# Altium schematic sheet MCU_PWR.SchDoc
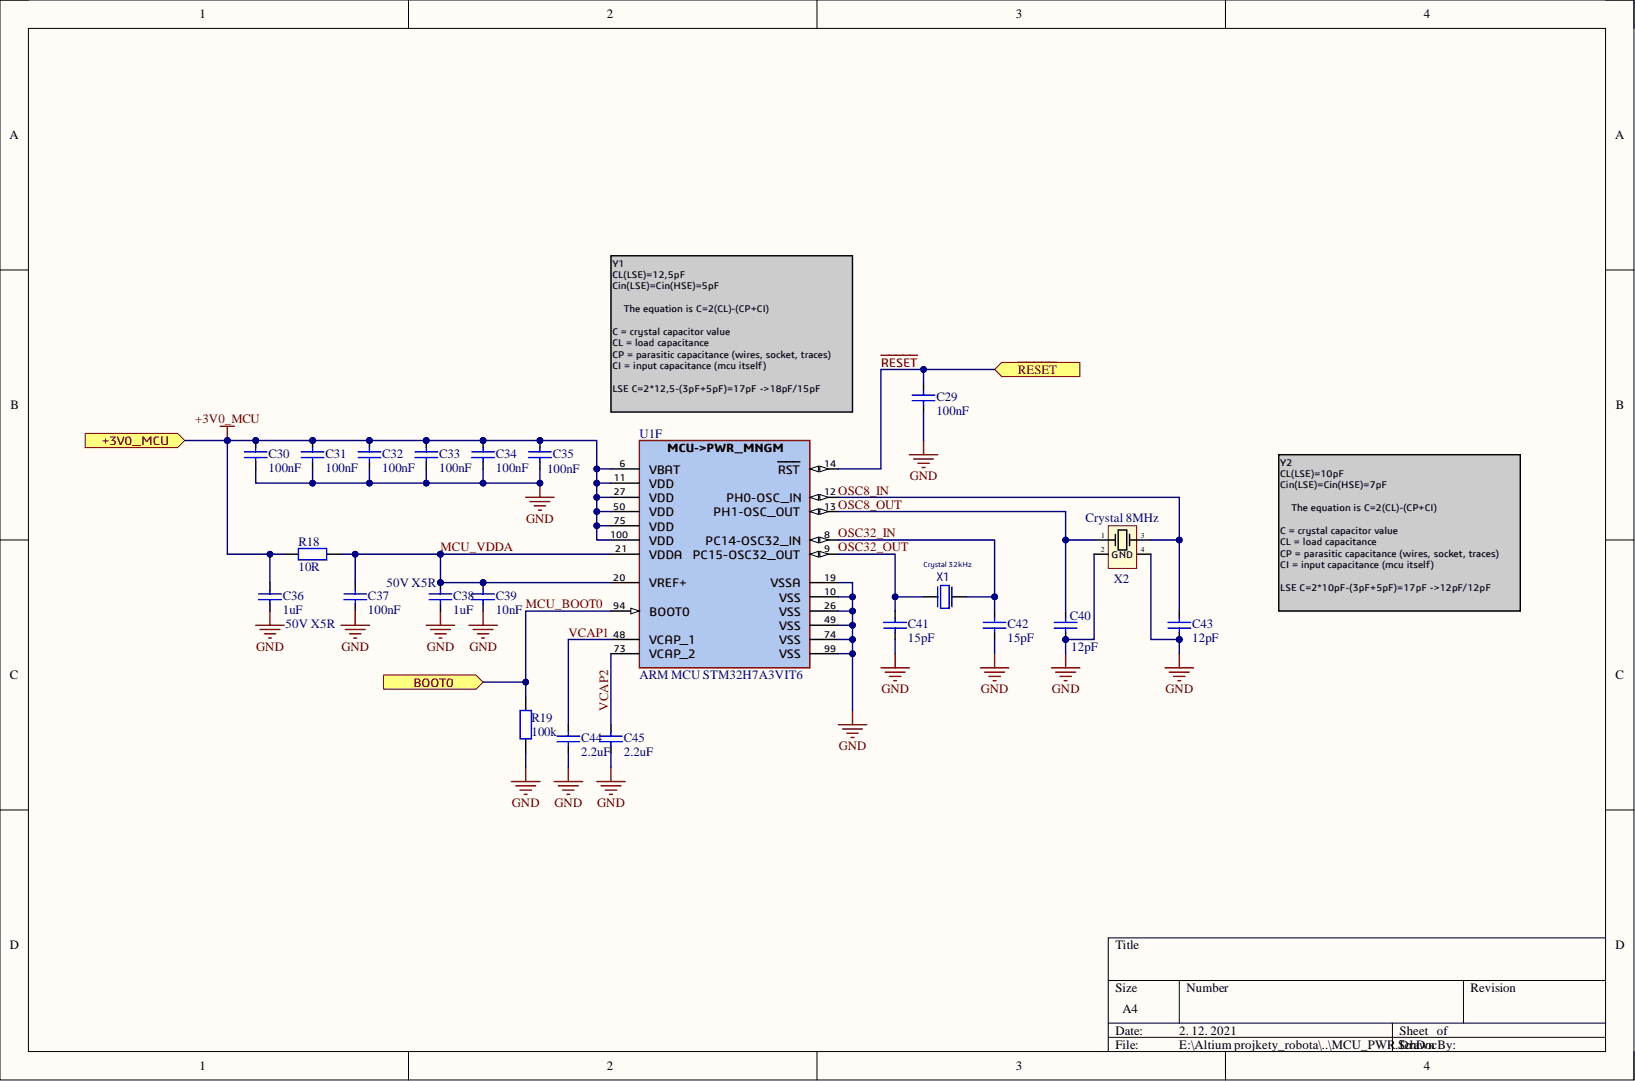
[diagram p0: MCU_PWR.SchDoc]

## Parts
C29  100nF  [CAPACITOR_0402_(1005)]
C30  100nF  [CAPACITOR_0402_(1005)]
C31  100nF  [CAPACITOR_0402_(1005)]
C32  100nF  [CAPACITOR_0402_(1005)]
C33  100nF  [CAPACITOR_0402_(1005)]
C34  100nF  [CAPACITOR_0402_(1005)]
C35  100nF  [CAPACITOR_0402_(1005)]
C36  1uF  Voltage Rating (DC): 50V  [CAPACITOR_0603_(1608)]
C37  100nF  [CAPACITOR_0402_(1005)]
C38  1uF  Dielectric: X5R  [CAPACITOR_0603_(1608)]
C39  10nF  [CAPACITOR_0402_(1005)]
C40  12pF  [CAPACITOR_0402_(1005)]
C41  15pF  [CAPACITOR_0402_(1005)]
C42  15pF  [CAPACITOR_0402_(1005)]
C43  12pF  [CAPACITOR_0402_(1005)]
C44  2.2uF  [CAPACITOR_0603_(1608)]
C45  2.2uF  [CAPACITOR_0603_(1608)]
R18  10R  [RESISTOR_0603_(1608)]
R19  100k  [RESISTOR_0603_(1608)]
U1  ARM MCU STM32H7A3VIT6  Manufacturer Part Number: STM32H7A3VIT6  [STM-LQFP100_N - Edited]  (part F)
X1  Crystal 32kHz  [ABS07]
X2  Crystal 8MHz  Frequency: 8MHz  [SMD Crystal 5.0 X 3.2 X 1.1mm]

## Ports
+3V0_MCU, BOOT0, ~{RESET}

## Nets
GND: C29.2 C30.2 C31.2 C32.2 C33.2 C34.2 C35.2 C36.2 C37.2 C38.2 C39.2 C40.1 C41.1 C42.1 C43.1 C44.1 C45.1 R19.1 U1.10(VSS) U1.19(VSSA) U1.26(VSS) U1.49(VSS) U1.74(VSS) U1.99(VSS) X2.2 X2.4(GND)
+3V0_MCU: C30.1 C31.1 C32.1 C33.1 C34.1 C35.1 C36.1 R18.1 U1.6(VBAT) U1.11(VDD) U1.27(VDD) U1.50(VDD) U1.75(VDD) U1.100(VDD) ; port +3V0_MCU
MCU_BOOT0: R19.2 U1.94(BOOT0) ; port BOOT0
MCU_VDDA: C37.1 C38.1 C39.1 R18.2 U1.20(VREF+) U1.21(VDDA)
OSC8_IN: C43.2 U1.12(PH0-OSC_IN) X2.3
OSC8_OUT: C40.2 U1.13(PH1-OSC_OUT) X2.1
OSC32_IN: C42.2 U1.8(PC14-OSC32_IN) X1.1
OSC32_OUT: C41.2 U1.9(PC15-OSC32_OUT) X1.2
~{RESET}: C29.1 U1.14(RST) ; port ~{RESET}
VCAP1: C44.2 U1.48(VCAP_1)
VCAP2: C45.2 U1.73(VCAP_2)

## Library data of the parts
C29-C35 C37 C39-C43 = CAPACITOR: ESR: 0.28R; Voltage Rating: 10V; Component Kind: Standard; Description: CAPACITOR; Library Name: RLCFB.SchLib
  C29-C35 C37: Capacitance: 100nF
  C39: Capacitance: 10nF
  C40 C43: Capacitance: 12pF
  C41 C42: Capacitance: 15pF
C36 = CAPACITOR: Manufacturer: Taiyo Yuden; Manufacturer Part Number 1: UMK107BJ105KA-T; Dielectric: X5R; ESR: 0.28R; Tolerance: 10%; Voltage Rating: 50V X5R; Capacitance: 1uF; Case Code (Imperial): 0603; Case Code (Metric): 1608; Case/Package: 0603; Component Kind: Standard; ComponentLink1Description: https://datasheet.ciiva.com/5744/1697715-5744913.pdf?src-supplier=Farnell; ComponentLink1URL: https://datasheet.ciiva.com/5744/1697715-5744913.pdf?src-supplier=Farnell; ComponentLink2Description: https://datasheet.ciiva.com/11601/umk107bj105ka-t-ss-11601811.pdf?src-supplier=Avnet; ComponentLink2URL: https://datasheet.ciiva.com/11601/umk107bj105ka-t-ss-11601811.pdf?src-supplier=Avnet; ComponentLink3Description: https://datasheet.ciiva.com/15922/336umk107bj105ka-t-15922611.pdf?src-supplier=Onlinecomponents.com; ComponentLink3URL: https://datasheet.ciiva.com/15922/336umk107bj105ka-t-15922611.pdf?src-supplier=Onlinecomponents.com; ComponentLink4Description: https://datasheet.ciiva.com/134/umk107bj105ka-t-ss-134740.pdf?src-supplier=Digi-Key; ComponentLink4URL: https://datasheet.ciiva.com/134/umk107bj105ka-t-ss-134740.pdf?src-supplier=Digi-Key; ComponentLink5Description: https://datasheet.ciiva.com/30199/43umk107bj105ka-t-30199791.pdf?src-supplier=Onlinecomponents.com; ComponentLink5URL: https://datasheet.ciiva.com/30199/43umk107bj105ka-t-30199791.pdf?src-supplier=Onlinecomponents.com; ComponentLink6Description: https://datasheet.ciiva.com/22694/1697715-22694278.pdf?src-supplier=Element14; ComponentLink6URL: https://datasheet.ciiva.com/22694/1697715-22694278.pdf?src-supplier=Element14; ComponentLink7Description: https://datasheet.ciiva.com/7975/1697715-7975608.pdf?src-supplier=Element14; ComponentLink7URL: https://datasheet.ciiva.com/7975/1697715-7975608.pdf?src-supplier=Element14; ComponentLink8Description: https://datasheet.ciiva.com/7975/mlcc-all-e-7975898.pdf?src-supplier=Element14; ComponentLink8URL: https://datasheet.ciiva.com/7975/mlcc-all-e-7975898.pdf?src-supplier=Element14; ComponentLink9Description: https://datasheet.ciiva.com/5427/mlcc-all-e-541559-5427036.pdf?src-supplier=Mouser; ComponentLink9URL: https://datasheet.ciiva.com/5427/mlcc-all-e-541559-5427036.pdf?src-supplier=Mouser; Contact Plating: Nickel; Depth: 0.8mm; Description: CAPACITOR; Halogen Free: Halogen Free; Height: 0.8mm; Length: 1.6mm; Max Operating Temperature: 85°C; Min Operating Temperature: -55°C; Mount: Surface Mount; Number of Pins: 2; Package Quantity: 1; Packaging: Tape and Reel; Pricing 1: 10=0,14300, 100=0,06560, 500=0,04260, 1000=0,03940, 2000=0,03750, 4000=0,02830, 20000=0,02630 (EUR); Radiation Hardening: No; REACH SVHC: Unknown; RoHS: Yes; Stock 1: 372983; Supplier 1: Farnell; Supplier Part Number 1: 2112858; Termination: SMD/SMT; Thickness: 0.035inch; Width: 0.031inch; Library Name: RLCFB.SchLib
C38 = CAPACITOR: Manufacturer: Taiyo Yuden; Manufacturer Part Number 1: UMK107BJ105KA-T; ESR: 0.28R; Tolerance: 10%; Voltage Rating: 50V X5R; Voltage Rating (DC): 50V; Capacitance: 1uF; Case Code (Imperial): 0603; Case Code (Metric): 1608; Case/Package: 0603; Component Kind: Standard; ComponentLink1Description: https://datasheet.ciiva.com/5744/1697715-5744913.pdf?src-supplier=Farnell; ComponentLink1URL: https://datasheet.ciiva.com/5744/1697715-5744913.pdf?src-supplier=Farnell; ComponentLink2Description: https://datasheet.ciiva.com/11601/umk107bj105ka-t-ss-11601811.pdf?src-supplier=Avnet; ComponentLink2URL: https://datasheet.ciiva.com/11601/umk107bj105ka-t-ss-11601811.pdf?src-supplier=Avnet; ComponentLink3Description: https://datasheet.ciiva.com/15922/336umk107bj105ka-t-15922611.pdf?src-supplier=Onlinecomponents.com; ComponentLink3URL: https://datasheet.ciiva.com/15922/336umk107bj105ka-t-15922611.pdf?src-supplier=Onlinecomponents.com; ComponentLink4Description: https://datasheet.ciiva.com/134/umk107bj105ka-t-ss-134740.pdf?src-supplier=Digi-Key; ComponentLink4URL: https://datasheet.ciiva.com/134/umk107bj105ka-t-ss-134740.pdf?src-supplier=Digi-Key; ComponentLink5Description: https://datasheet.ciiva.com/30199/43umk107bj105ka-t-30199791.pdf?src-supplier=Onlinecomponents.com; ComponentLink5URL: https://datasheet.ciiva.com/30199/43umk107bj105ka-t-30199791.pdf?src-supplier=Onlinecomponents.com; ComponentLink6Description: https://datasheet.ciiva.com/22694/1697715-22694278.pdf?src-supplier=Element14; ComponentLink6URL: https://datasheet.ciiva.com/22694/1697715-22694278.pdf?src-supplier=Element14; ComponentLink7Description: https://datasheet.ciiva.com/7975/1697715-7975608.pdf?src-supplier=Element14; ComponentLink7URL: https://datasheet.ciiva.com/7975/1697715-7975608.pdf?src-supplier=Element14; ComponentLink8Description: https://datasheet.ciiva.com/7975/mlcc-all-e-7975898.pdf?src-supplier=Element14; ComponentLink8URL: https://datasheet.ciiva.com/7975/mlcc-all-e-7975898.pdf?src-supplier=Element14; ComponentLink9Description: https://datasheet.ciiva.com/5427/mlcc-all-e-541559-5427036.pdf?src-supplier=Mouser; ComponentLink9URL: https://datasheet.ciiva.com/5427/mlcc-all-e-541559-5427036.pdf?src-supplier=Mouser; Contact Plating: Nickel; Depth: 0.8mm; Description: CAPACITOR; Halogen Free: Halogen Free; Height: 0.8mm; Length: 1.6mm; Max Operating Temperature: 85°C; Min Operating Temperature: -55°C; Mount: Surface Mount; Number of Pins: 2; Package Quantity: 1; Packaging: Tape and Reel; Pricing 1: 10=0,14300, 100=0,06560, 500=0,04260, 1000=0,03940, 2000=0,03750, 4000=0,02830, 20000=0,02630 (EUR); Radiation Hardening: No; REACH SVHC: Unknown; RoHS: Yes; Stock 1: 372983; Supplier 1: Farnell; Supplier Part Number 1: 2112858; Termination: SMD/SMT; Thickness: 0.035inch; Width: 0.031inch; Library Name: RLCFB.SchLib
C44 C45 = CAPACITOR: Manufacturer: Murata; Manufacturer Part Number 1: GRT188R61H225KE13D; Dielectric: X5R; Tolerance: 10%; Voltage Rating: 50V; Capacitance: 2.2uF; Case Code (Imperial): 0603; Case Code (Metric): 1608; Case/Package: 0603; Component Kind: Standard; ComponentLink1Description: http://www.farnell.com/datasheets/2165960.pdf?src-supplier=Farnell; ComponentLink1URL: http://www.farnell.com/datasheets/2165960.pdf?src-supplier=Farnell; ComponentLink2Description: http://search.murata.co.jp/Ceramy/image/img/A01X/G101/ENG/GRT188R61H225KE13-01.pdf?src-supplier=Digi-Key; ComponentLink2URL: http://search.murata.co.jp/Ceramy/image/img/A01X/G101/ENG/GRT188R61H225KE13-01.pdf?src-supplier=Digi-Key; Description: CAPACITOR; Length: 0.063inch; Max Operating Temperature: 85°C; Min Operating Temperature: -55°C; Mount: Surface Mount; Packaging: Tape and Reel; Pricing 1: 10=0,29600, 100=0,13900, 500=0,09800, 1000=0,08370, 2000=0,08180, 4000=0,05810, 20000=0,05620 (EUR); Ratings: AEC-Q200; RoHS: Yes; Stock 1: 31469; Supplier 1: Farnell; Supplier Part Number 1: 2672161; Thickness: 0.035inch; Voltage Rating (DC): 50V; Width: 0.031inch; Library Name: RLCFB.SchLib
R18 R19 = RESISTOR: Power Rating: 500mW; Component Kind: Standard; Description: RESISTOR; Library Name: RLCFB.SchLib
  R18: Resistance: 10R
  R19: Resistance: 100k
U1 = STM32H7A3VIT6_LQFP100: STM32H7A3VIT6 -  32-bit Arm® Cortex®-M7 280 MHz MCUs, up to 2-Mbyte Flash memory, 1.4Mbyte RAM, 46 com. and analog interfaces; Manufacturer: STMicroelectronics; Category: Microcontrollers; Component Kind: Standard; ComponentLink1Description: Datasheet; ComponentLink1URL: https://www.st.com/resource/en/datasheet/stm32h7a3vi.pdf; Library Name: BB1_SCH_Library.SchLib; RoHS: No; Supplier 1: Mouser; Supplier Part Number 1: 511-STM32H7A3VIT6
X1 = xtal 32kHz: ABRACON - ABS07-32.768KHZ-T - CRYSTAL, 32.768KHZ, 12.5PF, SMD; Component Kind: Standard; ComponentLink1URL: http://www.farnell.com/datasheets/1700986.pdf; Library Name: BB1_SCH_Library.SchLib; Manufacturer: ABRACON; Manufacturer Part Number: ABS07-32.768KHZ-T; Supplier 1: Farnell; Supplier Part Number 1: 2101347
X2 = Crystal ABM3B 8MHz: CRYSTAL 8.0000MHZ 10PF SMD; Manufacturer: Abracon; Manufacturer Part Number 1: ABM3B-8.000MHZ-10-1-U-T; ESR (Equivalent Series Resistance): 200R; Frequency Stability: 10ppm; Case/Package: SMD/SMT; Category: Crystals; Component Kind: Standard; ComponentLink1Description: https://datasheet.ciiva.com/855/abm3b-855873.pdf?src-supplier=Digi-Key; ComponentLink1URL: https://datasheet.ciiva.com/855/abm3b-855873.pdf?src-supplier=Digi-Key; ComponentLink2Description: https://datasheet.ciiva.com/855/abm3b-855874.pdf?src-supplier=Digi-Key; ComponentLink2URL: https://datasheet.ciiva.com/855/abm3b-855874.pdf?src-supplier=Digi-Key; ComponentLink3Description: https://datasheet.ciiva.com/855/abm3b-855875.pdf?src-supplier=Digi-Key; ComponentLink3URL: https://datasheet.ciiva.com/855/abm3b-855875.pdf?src-supplier=Digi-Key; ComponentLink4Description: https://datasheet.ciiva.com/16378/abm3b-16378027.pdf?src-supplier=Future+Electronics; ComponentLink4URL: https://datasheet.ciiva.com/16378/abm3b-16378027.pdf?src-supplier=Future+Electronics; ComponentLink5Description: https://datasheet.ciiva.com/3175/abm3b-3175853.pdf?src-supplier=Avnet; ComponentLink5URL: https://datasheet.ciiva.com/3175/abm3b-3175853.pdf?src-supplier=Avnet; Depth: 3.2mm; Frequency Tolerance: 10ppm; Height: 0.043inch; Lead Free: Lead Free; Length: 0.197inch; Library Name: BB1_SCH_Library.SchLib; Load Capacitance: 10pF; Max Operating Temperature: 60°C; Min Operating Temperature: -10°C; Mount: Surface Mount; Number of Pins: 4; Packaging: Tape and Reel; Pricing 1: 1=0,69000, 10=0,57800, 50=0,51900, 100=0,46200, 500=0,43800, 1000=0,43800 (USD); REACH SVHC: No SVHC; RoHS: Yes; Series Resistance: 200R; Stock 1: 9293; Supplier 1: Mouser; Supplier Part Number 1: 815-ABM3B8MHZ101UT; Width: 0.126inch
